# Altium schematic sheet Connectors.SchDoc
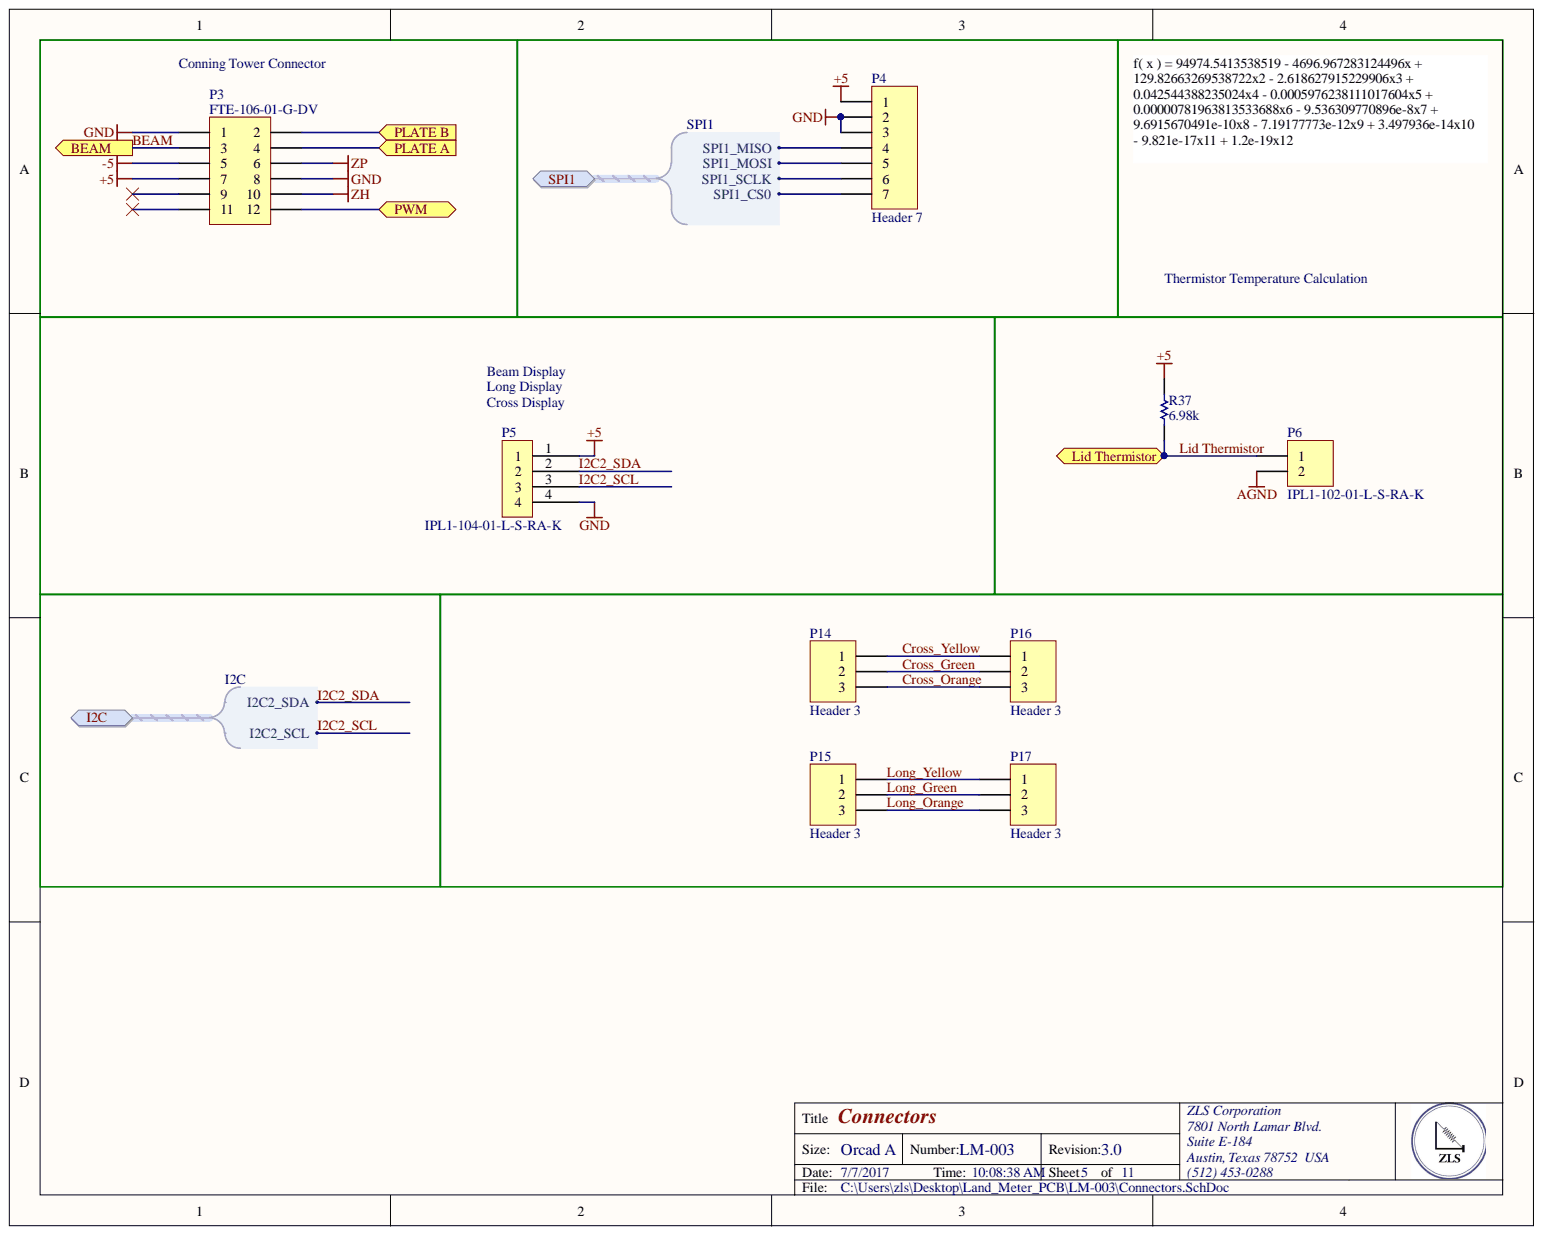
[diagram p0: Connectors.SchDoc]

## Parts
P3  FTE-106-01-G-DV  [FTSH-106-01]
P4  Header 7  [HDR1X7]
P5  IPL1-104-01-L-S-RA-K  [IPL1-104-01]
P6  IPL1-102-01-L-S-RA-K  [IPL1-102-01]
P14  Header 3  [HDR1X3]
P15  Header 3  [HDR1X3]
P16  Header 3  [TLW-103-01-G-S-RA]
P17  Header 3  [TLW-103-01-G-S-RA]
R37  Resistance (Ohms): 6.98k  [RESC0805(2012)_N]  (hidden comment: 6K98 1% 0805(2012))

## Ports
BEAM, I2C, I2C.I2C2_SCL, I2C.I2C2_SDA, Lid Thermistor, PLATE A, PLATE B, PWM, SPI1, SPI1.SPI1_CS0, SPI1.SPI1_MISO, SPI1.SPI1_MOSI, SPI1.SPI1_SCLK

## Nets
+5: P3.7 P4.1 P5.1 R37.2
-5: P3.5
AGND: P6.2
GND: P3.1 P3.8 P4.2 P4.3 P5.4
ZH: P3.10
ZP: P3.6
BEAM: P3.3 ; port BEAM
Cross_Green: P14.2 P16.2
Cross_Orange: P14.3 P16.3
Cross_Yellow: P14.1 P16.1
I2C2_SCL: P5.3 ; port I2C
I2C2_SDA: P5.2 ; port I2C
Lid Thermistor: P6.1 R37.1 ; port Lid Thermistor
Long_Green: P15.2 P17.2
Long_Orange: P15.3 P17.3
Long_Yellow: P15.1 P17.1
PLATE A: P3.4 ; port PLATE A
PLATE B: P3.2 ; port PLATE B
PWM: P3.12 ; port PWM
NetP4_4: P4.4 ; port SPI1
NetP4_5: P4.5 ; port SPI1
NetP4_6: P4.6 ; port SPI1
NetP4_7: P4.7 ; port SPI1

Not connected: P3 9 11

## Library data of the parts
P3 = Header 6X2: Header, 6-Pin, Dual row; Manufacturer: Samtec; Connector Type: Male Header; Contact Termination: Surface Mount Vertical; Molding Height Above Board: 0.067" (1.70mm); No. of Contacts: 12; No. of positions: 6; No. of Rows: 2; Number of Positions Loaded: All; Pitch: 0.8mm; Pitch Spacing: 0.8; Row Spacing: 0.047" (1.20mm); Category: Connectors, Interconnects; Color: Black; Component Kind: Standard; ComponentLink1URL: http://www.samtec.com/; ComponentLink2URL: http://www.samtec.com/technical-specifications/Default.aspx?SeriesMaster=FTE; ComponentLink3Description: http://www.samtec.com/documents/webfiles/pdf/FTE_SM.PDF; ComponentLink3URL: http://www.samtec.com/documents/webfiles/pdf/FTE_SM.PDF; ComponentLink4Description: http://www.samtec.com/ftppub/pdf/FTE_SM.PDF; ComponentLink4URL: http://www.samtec.com/ftppub/pdf/FTE_SM.PDF; Contact Finish: Gold; Contact Finish Thickness: 10µin (0.25µm); Contact Material: Phosphor Bronze; Contact Mating Length: 0.075" (1.90mm); Contact Plating: Gold; Features: -; Gender: Header; Height Stacking (Mating): -; LatestRevisionDate: 17-Jul-2002; LatestRevisionNote: Re-released for DXP Platform.; Manufacturer Part Number: FTE-106-01-G-DV; Mounting Technology: Surface Mount; Mounting Type: Surface Mount; Number of Positions: 12; Number of Rows: 2; Packaging: Tube; Pricing 1: 1=3.26, 10=2.85, 100=2.43, 500=2, 1000=1.72, 5000=1.55, 10000=1.48 (USD); Pricing 2: 1=7.49, 10=6.49, 100=5.4911, 500=4.36794, 1000=3.55676, 5000=3.24476, 10000=3.11996 (USD); RoHS: RoHS Compliant; Series: FTE; Stock 1: 0; Stock 2: 0; Supplier 1: Newark; Supplier 2: Digi-Key; Supplier Part Number 1: 11P4479; Supplier Part Number 2: SAM1144-06-ND; Termination: Solder; Library Name: Conning Tower Adapter.SchLib; Publisher: Altium Limited
P4 = Header 7: Header, 7-Pin; Component Kind: Standard; LatestRevisionDate: 17-Jul-2002; LatestRevisionNote: Re-released for DXP Platform.; Library Name: Miscellaneous Connectors.IntLib; Signal Integrity: Connector; Publisher: Altium Limited
P5 = 53047-0410: .100 TERMINAL ASSY; Manufacturer 1: Samtec Inc.; Category 1: Connectors, Interconnects; Connector Type: Header; Contact Length - Mating: 0.215" (5.46mm); Contact Length - Post: 0.085" (2.16mm); Material Flammability Rating: UL94 V-0; Number of Positions: 4; Number of Positions Loaded: All; Pitch - Mating: 0.100" (2.54mm); Shrouding: Shrouded - 4 Wall; Style: Board to Cable/Wire; Voltage Rating: 675VAC, 954VDC; Applications: -; Component Kind: Standard; ComponentLink1Description: http://suddendocs.samtec.com/prints/ipl1-1xx-xx-xxx-s-xx-x-xx-mkt.pdf; ComponentLink1URL: http://suddendocs.samtec.com/prints/ipl1-1xx-xx-xxx-s-xx-x-xx-mkt.pdf; Contact Finish - Mating: Gold; Contact Finish - Post: Tin; Contact Finish Thickness - Mating: 10µin (0.25µm); Contact Finish Thickness - Post: -; Contact Material: Phosphor Bronze; Contact Shape: Square; Contact Type: Male Pin; Current Rating: -; Description 1: .100 TERMINAL ASSY; Fastening Type: Locking Ramp; Features: -; Ingress Protection: -; Insulation Color: Natural; Insulation Height: 0.240" (6.10mm); Insulation Material: Liquid Crystal Polymer (LCP); Manufacturer Part Number 1: IPL1-104-01-L-S-RA-K; Mated Stacking Heights: -; Mounting Type: Through Hole, Right Angle; Number of Rows: 1; Operating Temperature: -55°C ~ 125°C; Overall Contact Length: -; Packaging: Tube; Part Status: Active; Pricing 1: 1=2.53, 10=2.424, 25=2.222, 50=2.121, 100=2.02, 250=1.76752, 500=1.717, 1000=1.4645, 2500=1.3635 (USD); RoHS 1: RoHS Compliant; Row Spacing - Mating: -; Stock 1: 100; Supplier 1: Digi-Key; Supplier Part Number 1: SAM9597-ND; Termination: Solder; Library Name: ZLS_LIB.SchLib
P6 = Header 2H: CONN SHROUDED PWR HEADER 2POS; Manufacturer 1: Samtec Inc.; Category 1: Connectors, Interconnects; Contact Length - Mating: 0.215" (5.46mm); Contact Length - Post: 0.085" (2.16mm); Material Flammability Rating: UL94 V-0; Number of Positions: 2; Number of Positions Loaded: All; Pitch - Mating: 0.100" (2.54mm); Shrouding: Shrouded - 4 Wall; Style: Board to Cable/Wire; Voltage Rating: 675VAC, 954VDC; Applications: -; Component Kind: Standard; ComponentLink1Description: http://suddendocs.samtec.com/prints/ipl1-1xx-xx-xxx-s-xx-x-xx-mkt.pdf; ComponentLink1URL: http://suddendocs.samtec.com/prints/ipl1-1xx-xx-xxx-s-xx-x-xx-mkt.pdf; Connector Type: Header; Contact Finish - Mating: Gold; Contact Finish - Post: Tin; Contact Finish Thickness - Mating: 10µin (0.25µm); Contact Finish Thickness - Post: -; Contact Material: Phosphor Bronze; Contact Shape: Square; Contact Type: Male Pin; Current Rating: -; Description 1: CONN SHROUDED PWR HEADER 2POS; Fastening Type: Locking Ramp; Features: -; Ingress Protection: -; Insulation Color: Natural; Insulation Height: 0.240" (6.10mm); Insulation Material: Liquid Crystal Polymer (LCP); Library Name: Miscellaneous Connectors.IntLib; Manufacturer Part Number 1: IPL1-102-01-L-S-RA-K; Mated Stacking Heights: -; Mounting Type: Through Hole, Right Angle; Number of Rows: 1; Operating Temperature: -55°C ~ 125°C; Overall Contact Length: -; Packaging: Tube; Part Status: Active; Pricing 1: 1=2.44, 10=2.217, 25=2.0816, 50=1.991, 100=1.9005, 250=1.71952, 500=1.58376, 1000=1.3575, 2500=1.267 (USD); RoHS 1: RoHS Compliant; Row Spacing - Mating: -; Signal Integrity: Connector; Stock 1: 0; Supplier 1: Digi-Key; Supplier Part Number 1: SAM10566-ND; Termination: Solder
P14-P17 = Header 3: Header, 3-Pin; Component Kind: Standard; LatestRevisionDate: 17-Jul-2002; LatestRevisionNote: Re-released for DXP Platform.; Library Name: Miscellaneous Connectors.IntLib; Signal Integrity: Connector; Publisher: Altium Limited
R37 = CMP-1013-00606-1: 6K98 0.125W 1% 0805 (2012 Metric)  SMD; Manufacturer: Vishay Dale; Manufacturer Part Number: CRCW08056K98FKEA; Power (Watts): 0.125W, 1/8W; Temperature Coefficient: ±100ppm/°C; Category: Resistors; Component Kind: Standard; ComponentLink1Description: http://www.vishay.com/docs/20035/dcrcwe3.pdf; ComponentLink1URL: http://www.vishay.com/docs/20035/dcrcwe3.pdf; Composition: Thick Film; Failure Rate: -; Features: Automotive AEC-Q200; Height - Seated (Max): 0.020" (0.50mm); Library Name: Altium Content Vault; Operating Temperature: -55°C ~ 155°C; Package / Case: 0805 (2012 Metric); Packaging: Cut Tape (CT); Part Status: Active; Pricing 1: 1=0.1, 10=0.044, 100=0.0178, 1000=0.00802, 2500=0.00696 (USD); RoHS: RoHS Compliant; Size / Dimension: 0.079" L x 0.049" W (2.00mm x 1.25mm); Stock 1: 34245; Supplier 1: Digi-Key; Supplier Device Package: 0805; Supplier Part Number 1: 541-6.98KCCT-ND; Tolerance: ±1%
